# Altium schematic sheet USB.SchDoc
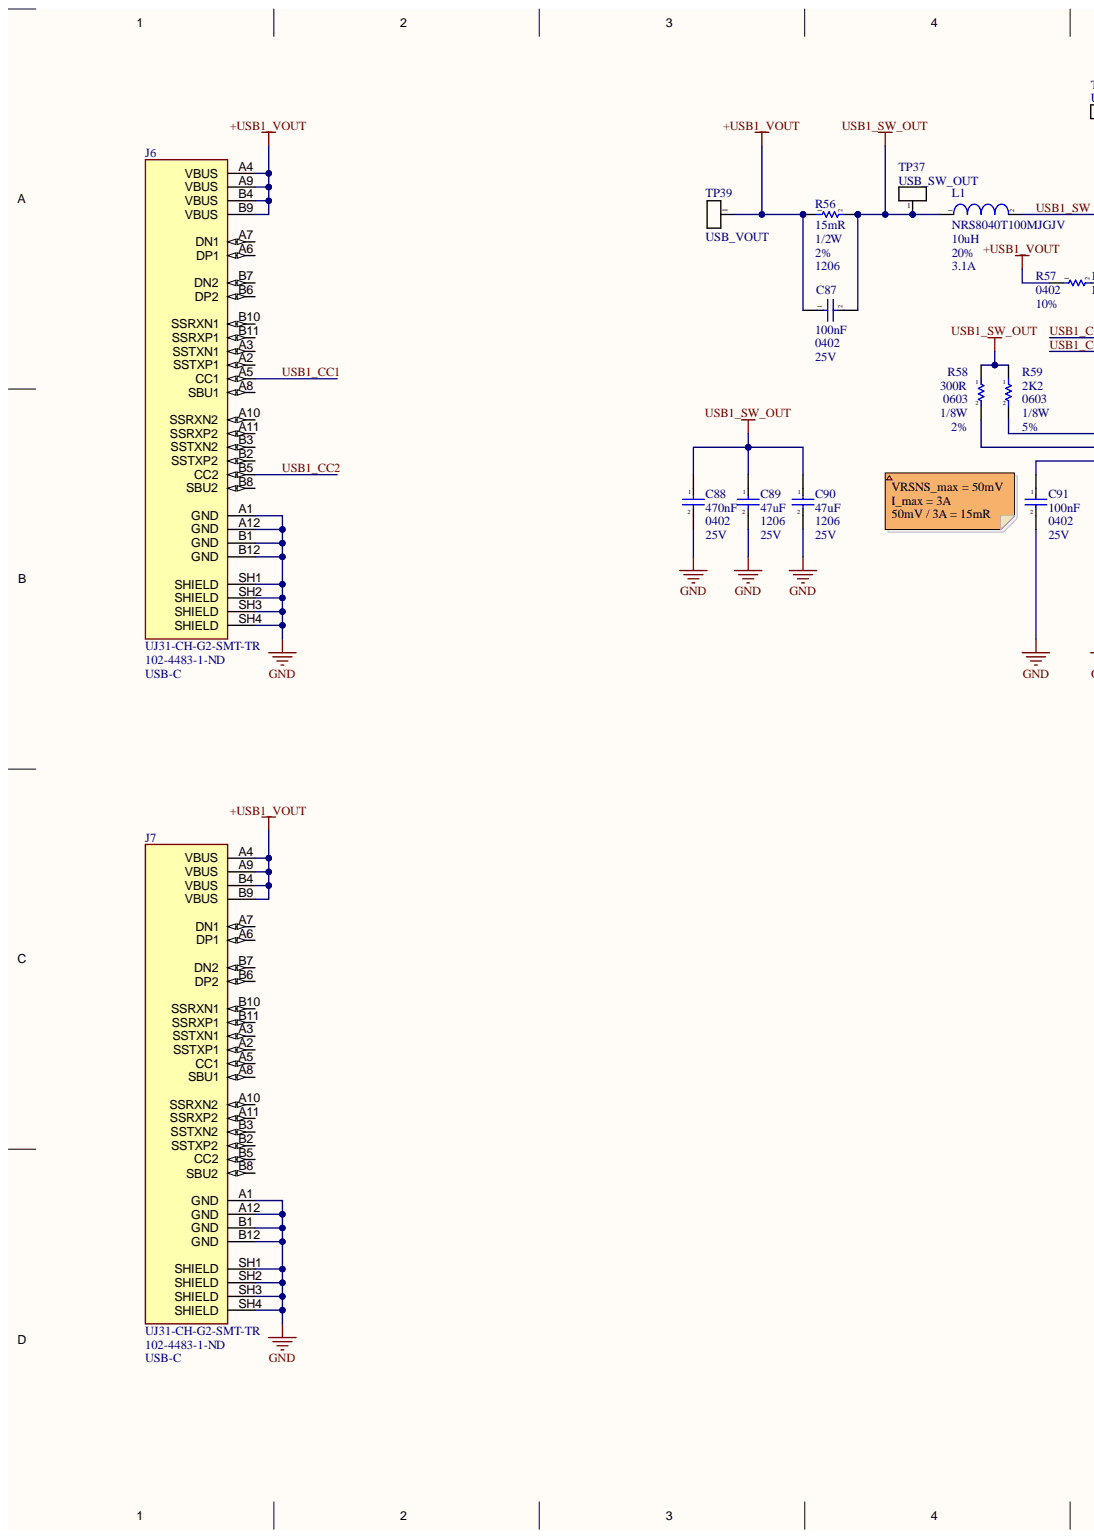
[diagram p0: USB.SchDoc, left]
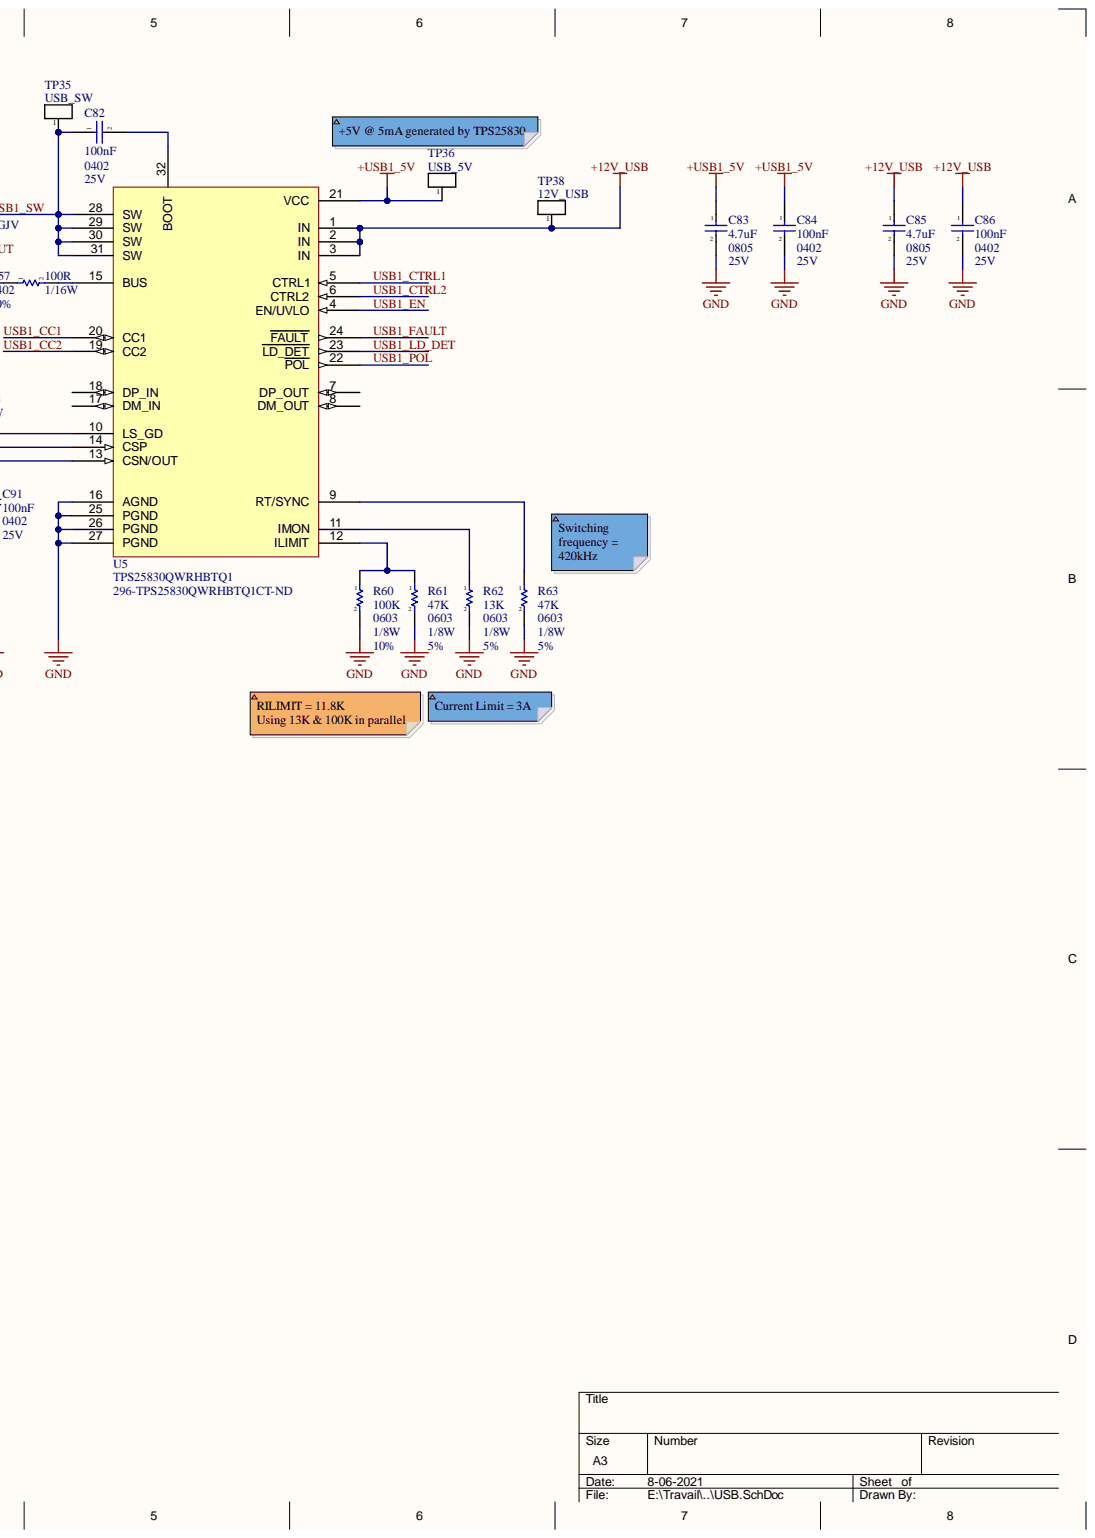
[diagram p1: USB.SchDoc, right]

## Parts
C82  100nF  [0402]
C83  4.7uF  Voltage - Rated: 25V  [0805]
C84  100nF  Voltage - Rated: 25V  [0402]
C85  4.7uF  Voltage - Rated: 25V  [0805]
C86  100nF  Voltage - Rated: 25V  [0402]
C87  100nF  Voltage - Rated: 25V  [0402]
C88  470nF  Voltage - Rated: 25V  [0402]
C89  47uF  Voltage - Rated: 25V  [1206]
C90  47uF  Voltage - Rated: 25V  [1206]
C91  100nF  Voltage - Rated: 25V  [0402]
J6  UJ31-CH-G2-SMT-TR  Connector Type: USB-C  Digikey Part Number: 102-4483-1-ND  [UJ31-CH-G2-SMT-TR]
J7  UJ31-CH-G2-SMT-TR  Connector Type: USB-C  Digikey Part Number: 102-4483-1-ND  [UJ31-CH-G2-SMT-TR]
L1  NRS8040T100MJGJV  Inductance: 10uH  Tolerance: 20%  [NRS8040T100MJGJV]
R56  15mR  Power: 1/2W  [RES_1206]
R57  100R  Package / Case: 0402  Power: 1/16W  Tolerance: 10%  [RES_0402]
R58  300R  Package / Case: 0603  Power: 1/8W  Tolerance: 2%  [RES_0603]
R59  2K2  Package / Case: 0603  Power: 1/8W  Tolerance: 5%  [RES_0603]
R60  100K  Package / Case: 0603  Power: 1/8W  Tolerance: 10%  [RES_0603]
R61  47K  Package / Case: 0603  Power: 1/8W  Tolerance: 5%  [RES_0603]
R62  13K  Package / Case: 0603  Power: 1/8W  Tolerance: 5%  [RES_0603]
R63  47K  Package / Case: 0603  Power: 1/8W  Tolerance: 5%  [RES_0603]
TP35  USB_SW  [TP_PAD_SMALL]
TP36  USB_5V  [TP_HOLE]
TP37  USB_SW_OUT  [TP_PAD]
TP38  12V_USB  [TP_HOLE]
TP39  USB_VOUT  [TP_HOLE]
U5  TPS25830QWRHBTQ1  Supplier Part Number 1: 296-TPS25830QWRHBTQ1CT-ND  [TPS25830QWRHBTQ1]

## Nets
+12V_USB: C85.1 C86.1 TP38.1 U5.1(IN) U5.2(IN) U5.3(IN)
+USB1_5V: C83.1 C84.1 TP36.1 U5.21(VCC)
+USB1_VOUT: C87.1 J6.A4(VBUS) J6.A9(VBUS) J6.B4(VBUS) J6.B9(VBUS) J7.A4(VBUS) J7.A9(VBUS) J7.B4(VBUS) J7.B9(VBUS) R56.1 R57.1 TP39.1
GND: C83.2 C84.2 C85.2 C86.2 C88.2 C89.2 C90.2 C91.2 J6.A1(GND) J6.A12(GND) J6.B1(GND) J6.B12(GND) J6.SH1(SHIELD) J6.SH2(SHIELD) J6.SH3(SHIELD) J6.SH4(SHIELD) J7.A1(GND) J7.A12(GND) J7.B1(GND) J7.B12(GND) J7.SH1(SHIELD) J7.SH2(SHIELD) J7.SH3(SHIELD) J7.SH4(SHIELD) R60.2 R61.2 R62.2 R63.2 U5.16(AGND) U5.25(PGND) U5.26(PGND) U5.27(PGND)
USB1_SW_OUT: C87.2 C88.1 C89.1 C90.1 L1.1 R56.2 R58.1 R59.1 TP37.1
USB1_CC1: J6.A5(CC1) U5.20(CC1)
USB1_CC2: J6.B5(CC2) U5.19(CC2)
USB1_CTRL1: U5.5(CTRL1)
USB1_CTRL2: U5.6(CTRL2)
USB1_EN: U5.4(EN/UVLO)
USB1_FAULT: U5.24(FAULT)
USB1_LD_DET: U5.23(LD_DET)
USB1_POL: U5.22(POL)
USB1_SW: C82.1 L1.2 TP35.1 U5.28(SW) U5.29(SW) U5.30(SW) U5.31(SW)
NetC82_2: C82.2 U5.32(BOOT)
NetC91_1: C91.1 U5.13(CSN/OUT)
NetR57_2: R57.2 U5.15(BUS)
NetR58_2: R58.2 U5.14(CSP)
NetR59_2: R59.2 U5.10(LS_GD)
NetR60_1: R60.1 R61.1 U5.12(ILIMIT)
NetR62_1: R62.1 U5.11(IMON)
NetR63_1: R63.1 U5.9(RT/SYNC)

Not connected: J6 A2(SSTXP1) A3(SSTXN1) A6(DP1) A7(DN1) A8(SBU1) A10(SSRXN2) A11(SSRXP2) B2(SSTXP2) B3(SSTXN2) B6(DP2) B7(DN2) B8(SBU2) B10(SSRXN1) B11(SSRXP1); J7 A2(SSTXP1) A3(SSTXN1) A5(CC1) A6(DP1) A7(DN1) A8(SBU1) A10(SSRXN2) A11(SSRXP2) B2(SSTXP2) B3(SSTXN2) B5(CC2) B6(DP2) B7(DN2) B8(SBU2) B10(SSRXN1) B11(SSRXP1); U5 7(DP_OUT) 8(DM_OUT) 17(DM_IN) 18(DP_IN)

## Library data of the parts
C82 = CAP_CER: Generic SMT Ceramic Capacitor; Voltage - Rated: 25V; Component Kind: Standard; ComponentLink1Description: Digikey; ComponentLink1URL: [Digikey Link]; ComponentLink2Description: Datasheet; ComponentLink2URL: [Datasheet Link]; Library Name: RaesLib.IntLib
C83-C91 = CAP_CER: Generic SMT Ceramic Capacitor; Component Kind: Standard; ComponentLink1Description: Digikey; ComponentLink1URL: [Digikey Link]; ComponentLink2Description: Datasheet; ComponentLink2URL: [Datasheet Link]
  C83 C85: Capacitance: 4.7uF
  C83-C88 C91: Library Name: RaesLib.IntLib
  C89 C90: Library Name: CryptLib.IntLib
J6 J7 = CONN_UJ31-CH-G2-SMT-TR: Type C, USB 3.1 Gen 2, 5 A, Right Angle, Surface Mount _SMT_, USB Receptacle; Mfr: CUI Devices; Current Rating: 6.25A; Number of Contacts: 24; Specifications: USB 3.2 Gen 2 (USB 3.1 Gen 2, Superspeed + (USB 3.1)); Voltage Rating: 20V; Component Kind: Standard; ComponentLink1Description: Digikey; ComponentLink1URL: https://www.digikey.ca/en/products/detail/cui-devices/UJ31-CH-G2-SMT-TR/8024057; Operating Temperature: -25C - 80C; Supplier 1: Digi-Key; Supplier Part Number 1: 102-4483-1-ND; Library Name: CryptLib.IntLib
L1 = IND_NRS8040T100MJGJV: Generic Inductor; Current Rating: 3.1A; Material: Ferrite; Resistance: 44.2mR; Component Kind: Standard; Operating Temperature: -40C - 125C; Package / Case: Nonstandard; Library Name: CryptLib.IntLib
R56 = RESISTOR: Tolerance: 2%; Component Kind: Standard; ComponentLink1Description: Digikey; Description: Resistor; Library Name: Symbols.SchLib; Package / Case: 1206
R57-R63 = RESISTOR: Component Kind: Standard; ComponentLink1Description: Digikey; Description: Resistor; Library Name: Symbols.SchLib
TP35-TP39 = TESTPOINT: Test Point; Component Kind: Standard; Library Name: Symbols.SchLib
U5 = IC_TPS25830QWRHBTQ1: Automotive, USB Protection PMIC 32-VQFN (5x5); Mfr: Texas Instruments; Rating: Automotive AEC-Q100; Supply Current: 700uA; Supply Voltage: 4.5V - 36V; Component Kind: Standard; Operating Temperature: -40C - 125C; Supplier 1: Digi-Key; Library Name: CryptLib.SchLib
